# Altium schematic sheet InputOutput.SchDoc(InputOutput)
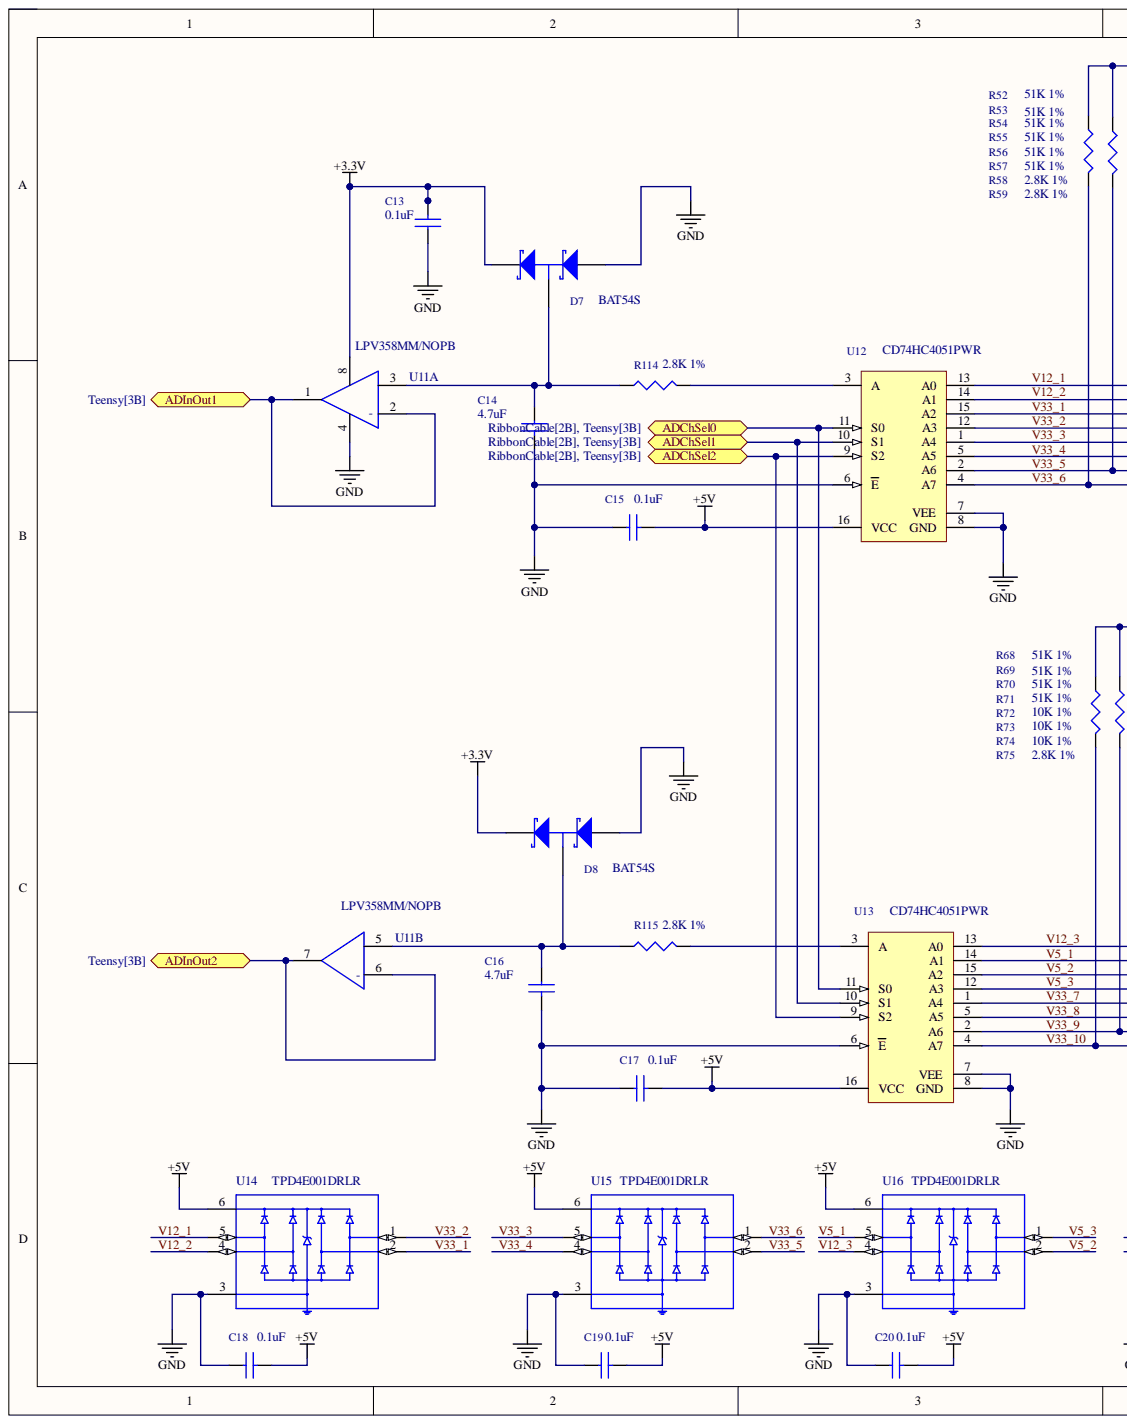
[diagram p0: InputOutput.SchDoc, left]
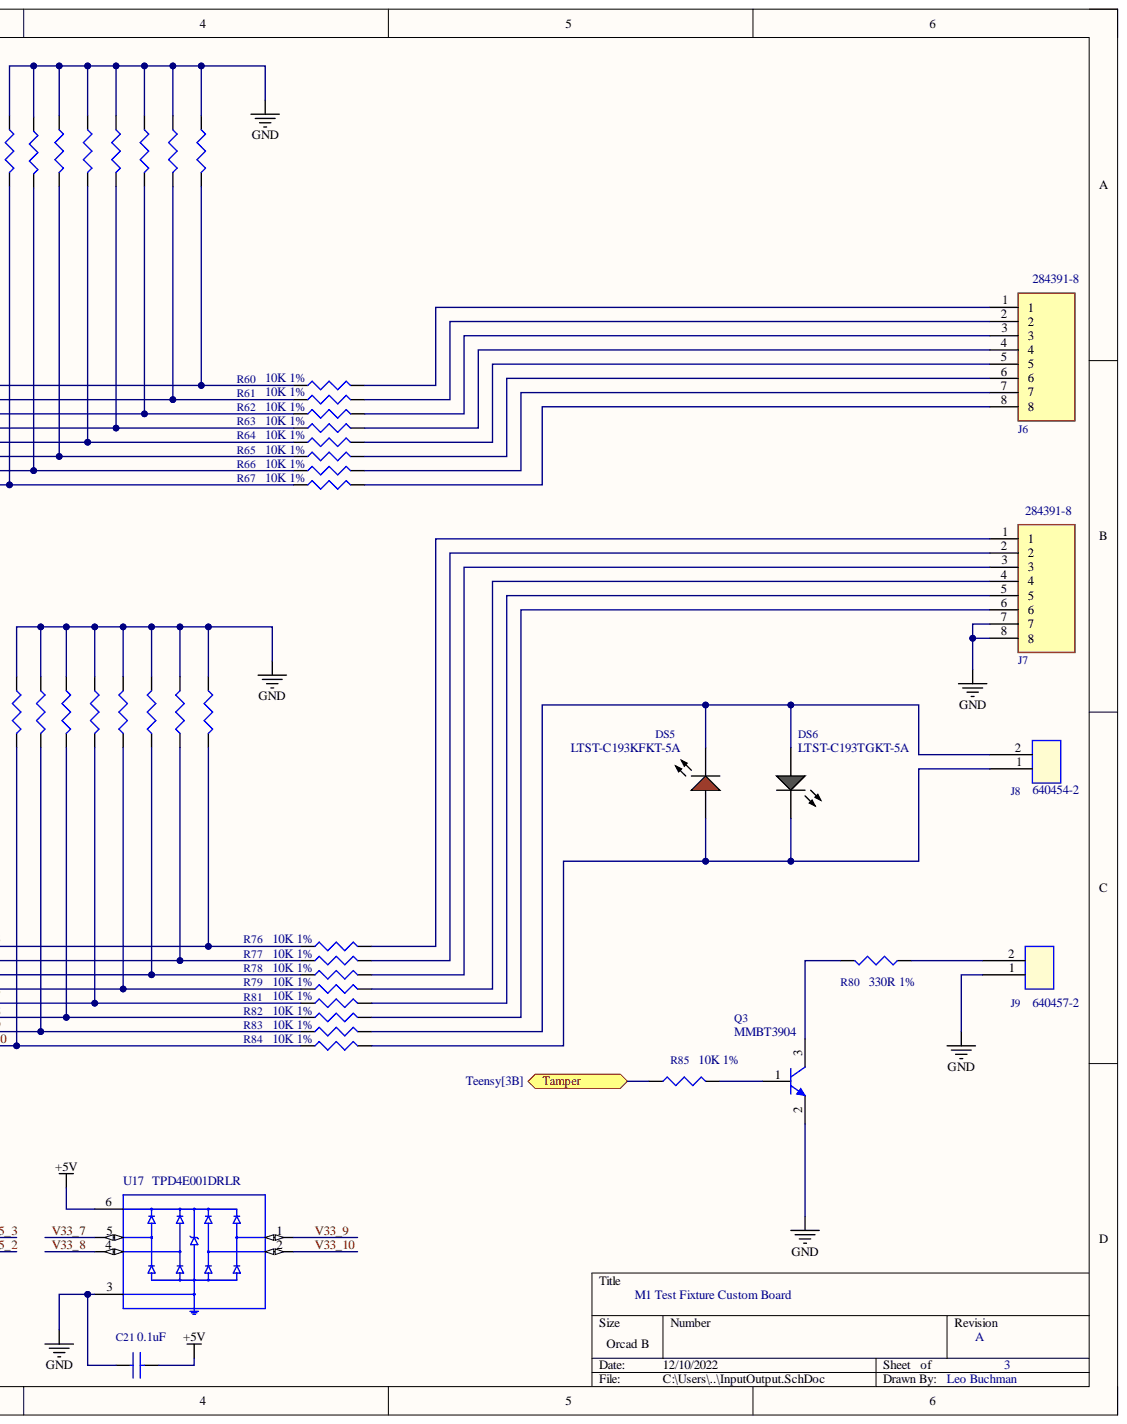
[diagram p1: InputOutput.SchDoc, right]

## Parts
C13  0.1uF  [C0402]
C14  4.7uF  [C0603]
C15  0.1uF  [C0402]
C16  4.7uF  [C0603]
C17  0.1uF  [C0402]
C18  0.1uF  [C0402]
C19  0.1uF  [C0402]
C20  0.1uF  [C0402]
C21  0.1uF  [C0402]
D7  BAT54S  [sot23-3]
D8  BAT54S  [sot23-3]
DS5  LTST-C193KFKT-5A  [LED-ORANGE_0603]
DS6  LTST-C193TGKT-5A  [LED-GREEN_0603]
J6  284391-8  [TE_284391-8]
J7  284391-8  [TE_284391-8]
J8  640454-2  [CON$5C$2P$5C$100LM]
J9  640457-2  [TE_640457-2]
Q3  MMBT3904  [sot23-3]
R52  51K 1%  Tolerance: 1%  [R0402]
R53  51K 1%  [R0402]
R54  51K 1%  [R0402]
R55  51K 1%  [R0402]
R56  51K 1%  [R0402]
R57  51K 1%  [R0402]
R58  2.8K 1%  [R0402]
R59  2.8K 1%  [R0402]
R60  10K 1%  Tolerance: 1%  [R0402]
R61  10K 1%  Tolerance: 1%  [R0402]
R62  10K 1%  Tolerance: 1%  [R0402]
R63  10K 1%  Tolerance: 1%  [R0402]
R64  10K 1%  Tolerance: 1%  [R0402]
R65  10K 1%  Tolerance: 1%  [R0402]
R66  10K 1%  Tolerance: 1%  [R0402]
R67  10K 1%  Tolerance: 1%  [R0402]
R68  51K 1%  Tolerance: 1%  [R0402]
R69  51K 1%  [R0402]
R70  51K 1%  [R0402]
R71  51K 1%  [R0402]
R72  10K 1%  [R0402]
R73  10K 1%  [R0402]
R74  10K 1%  [R0402]
R75  2.8K 1%  [R0402]
R76  10K 1%  Tolerance: 1%  [R0402]
R77  10K 1%  Tolerance: 1%  [R0402]
R78  10K 1%  Tolerance: 1%  [R0402]
R79  10K 1%  Tolerance: 1%  [R0402]
R80  330R 1%  Resistance: 330R  Tolerance: 1%  [R0402]
R81  10K 1%  Tolerance: 1%  [R0402]
R82  10K 1%  Tolerance: 1%  [R0402]
R83  10K 1%  Tolerance: 1%  [R0402]
R84  10K 1%  Tolerance: 1%  [R0402]
R85  10K 1%  Tolerance: 1%  [R0402]
R114  2.8K 1%  Tolerance: 1%  [R0402]
R115  2.8K 1%  Tolerance: 1%  [R0402]
U11  LPV358MM/NOPB  [MUA08A]  (parts A,B)
U12  CD74HC4051PWR  [16-TSSOP-4.4mm]
U13  CD74HC4051PWR  [16-TSSOP-4.4mm]
U14  TPD4E001DRLR  [SOTFL50P160X60-6N]
U15  TPD4E001DRLR  [SOTFL50P160X60-6N]
U16  TPD4E001DRLR  [SOTFL50P160X60-6N]
U17  TPD4E001DRLR  [SOTFL50P160X60-6N]

## Ports
ADChSel0, ADChSel1, ADChSel2, ADInOut1, ADInOut2, Tamper

## Nets
+3.3V: C13.2 D7.2 D8.2 U11.8
+5V: C15.2 C17.2 C18.2 C19.2 C20.2 C21.2 U12.16(VCC) U13.16(VCC) U14.6 U15.6 U16.6 U17.6
GND: C13.1 C14.2 C15.1 C16.2 C17.1 C18.1 C19.1 C20.1 C21.1 D7.1 D8.1 J7.7 J7.8 J9.1 Q3.2 R52.1 R53.1 R54.1 R55.1 R56.1 R57.1 R58.1 R59.1 R68.1 R69.1 R70.1 R71.1 R72.2 R73.2 R74.2 R75.1 U11.4 U12.6(E) U12.7(VEE) U12.8(GND) U13.6(E) U13.7(VEE) U13.8(GND) U14.3 U15.3 U16.3 U17.3
ADChSel0: U12.11(S0) U13.11(S0) ; port ADChSel0
ADChSel1: U12.10(S1) U13.10(S1) ; port ADChSel1
ADChSel2: U12.9(S2) U13.9(S2) ; port ADChSel2
ADInOut1: U11.1 U11.2(-) ; port ADInOut1
ADInOut2: U11.6(-) U11.7 ; port ADInOut2
Tamper: R85.1 ; port Tamper
V5_1: R74.1 R77.1 U13.14(A1) U16.5
V5_2: R73.1 R78.1 U13.15(A2) U16.2
V5_3: R72.1 R79.1 U13.12(A3) U16.1
V12_1: R59.2 R60.1 U12.13(A0) U14.5
V12_2: R58.2 R61.1 U12.14(A1) U14.4
V12_3: R75.2 R76.1 U13.13(A0) U16.4
V33_1: R57.2 R62.1 U12.15(A2) U14.2
V33_2: R56.2 R63.1 U12.12(A3) U14.1
V33_3: R55.2 R64.1 U12.1(A4) U15.5
V33_4: R54.2 R65.1 U12.5(A5) U15.4
V33_5: R53.2 R66.1 U12.2(A6) U15.2
V33_6: R52.2 R67.1 U12.4(A7) U15.1
V33_7: R71.2 R81.1 U13.1(A4) U17.5
V33_8: R70.2 R82.1 U13.5(A5) U17.4
V33_9: R69.2 R83.1 U13.2(A6) U17.1
V33_10: R68.2 R84.1 U13.4(A7) U17.2
NetC14_1: C14.1 D7.3 R114.1 U11.3
NetC16_1: C16.1 D8.3 R115.1 U11.5
NetDS5_1: DS5.1 DS6.2 J8.1 R84.2
NetDS5_2: DS5.2 DS6.1 J8.2 R83.2
NetJ6_1: J6.1 R60.2
NetJ6_2: J6.2 R61.2
NetJ6_3: J6.3 R62.2
NetJ6_4: J6.4 R63.2
NetJ6_5: J6.5 R64.2
NetJ6_6: J6.6 R65.2
NetJ6_7: J6.7 R66.2
NetJ6_8: J6.8 R67.2
NetJ7_1: J7.1 R76.2
NetJ7_2: J7.2 R77.2
NetJ7_3: J7.3 R78.2
NetJ7_4: J7.4 R79.2
NetJ7_5: J7.5 R81.2
NetJ7_6: J7.6 R82.2
NetJ9_2: J9.2 R80.1
NetQ3_1: Q3.1 R85.2
NetQ3_3: Q3.3 R80.2
NetR114_2: R114.2 U12.3(A)
NetR115_2: R115.2 U13.3(A)

## Library data of the parts
C13 C15 C17-C21 = Cap-00005: Cap Ceramic 0.1uF 50V X7R 10% Pad SMD 0402 125C Automotive T/R; Manufacturer: Murata; Manufacturer Part Number: GRT155R71H104KE01D; Database Table Name: Capacitors; Case Code (Imperial): 0402; Case Code (Metric): 1005; Case/Package: 0402; Category: Ceramic Capacitors; Component Kind: Standard; Dielectric Material: Ceramic; LCSC Part Number: C307331; Mount: Surface Mount; Number of Pins: 2; Part Name: Cap-00005; Pricing 1: 1=0.10000, 10=0.04700, 50=0.02580, 100=0.0211; RoHS: Yes; RoHS Compliant: Yes; Stock 1: 179948; Supplier 1: Digi-Key; Supplier Part Number 1: 490-GRT155R71H104KE01DCT-ND; Voltage Rating (DC): 50V; Library Name: Leo-Home-SQL-Lib.DbLib
C14 C16 = Cap-00001: CAP CER 4.7UF 16V X5R 0603; Manufacturer: Samsung; Manufacturer Part Number: CL10A475KO8NNNC; Database Table Name: Capacitors; Dielectric Material: Ceramic; Tolerance: 10%; Capacitance: 4.7uF; Case Code (Imperial): 0603; Case Code (Metric): 1608; Case/Package: 0603; Category: Ceramic Capacitors; Component Kind: Standard; ComponentLink1Description: https://datasheet.ciiva.com/25795/cl10a475ko8; ComponentLink1URL: https://datasheet.ciiva.com/25795/cl10a475ko8; ComponentLink2Description: https://datasheet.ciiva.com/26838/getdatashee; ComponentLink2URL: https://datasheet.ciiva.com/26838/getdatashee; ComponentLink3Description: https://datasheet.ciiva.com/29198/457663-da-0; ComponentLink3URL: https://datasheet.ciiva.com/29198/457663-da-0; Construction: Flat; Contact Plating: Tin; Depth: 0.8mm; Dielectric: X5R; Height: 0.8mm; LCSC Part Number: C19666; Length: 1.6mm; Material: Ceramic; Max Operating Temperature: 85°C; Min Operating Temperature: -55°C; Mount: Surface Mount; Number of Pins: 2; Package Quantity: 1; Packaging: Tape and Reel; Part Name: Cap-00001; Pricing 1: 1=0.13000, 10=0.09100, 50=0.05180, 100=0.0429; Radiation Hardening: No; RoHS: Yes; RoHS Compliant: Yes; Series: CL; Stock 1: 1593998; Supplier 1: Digi-Key; Supplier Part Number 1: 1276-1784-1-ND; Thickness: 0.035inch; Voltage: 16V; Voltage Rating: 16V; Width: 0.031inch; Library Name: Leo-Home-SQL-Lib.DbLib
D7 D8 = Diode-0009: DIODE SCHOTTKY 30V 150MA SOT23-3; Manufacturer: Sangdest Microelectronics; Database Table Name: Diodes; Elements: 2; Package Version: Rev. 12, 03/2016; Peak Reverse Current: 2uA; Recovery Time: 5ns; Component Kind: Standard; Component Type: Diodes; Datasheet URL: https://www.fairchildsemi.com/datasheets/BA/BAT54S.pdf; Datasheet Version: Rev. 2.3, 04/2016; LCSC Part Number: C47546; Lead Free: Lead Free; Manufacturer Part Number: BAT54S; Manufacturer URL: https://www.fairchildsemi.com/; Mount: Surface Mount; Mounting Technology: SM; Package Description: 3-Pin Small Outline Transistor, Body 2.92 x 1.325 mm, Pitch 0.95 mm; Package Reference: SOT23-3; Package URL: http://www.fairchildsemi.com/dwg/MA/MA03D.pdf; Part Name: Diode-0009; Pins: 3; Pricing 1: 1=0.43000, 10=0.35100, 100=0.18620, 500=0.122; Radiation Hardening: No; RoHS: Yes; Stock 1: 168252; Supplier 1: Digi-Key; Supplier Part Number 1: 1655-BAT54SCT-ND; Library Name: Leo-Home-SQL-Lib.DbLib
DS5 = Diode-0004: LED Orange CLEAR 0603 SMD; Manufacturer: Vishay Lite-On; Database Table Name: Diodes; Dominant Wavelength: 605nm; Forward (Drive) Current: 20mA; Forward Current: 5mA; Forward Voltage: 2.0V; Intensity: 22mcd; Lens Color: Colorless; Lens Style: Diffused,Colorless,Rectangular,Clear; Luminous Intensity: 28.1mcd; Number of Elements: 1; Viewing Angle: 130°; Case Code (Imperial): 0603; Case Code (Metric): 1608; Case/Package: 0603; Category: LEDs; Color: Orange; Component Kind: Standard; Datasheet URL: https://datasheet.ciiva.com/11773/0900766b80df3ad8-11773825.pdf; Datasheet Version: Rev. 2.0, 03/2010; Dimension: 1.6x0.8x0.36mm; Height: 0.35mm; Illumination Color: Orange; LCSC Part Number: C434425; Lead Free: Lead Free; Lens Transparency: Clear; Lens Type: Clear; Manufacturer Part Number: LTST-C193KFKT-5A; Manufacturer URL: http://www.rohm.com/web/global/; Max Operating Temperature: 85°C; Min Operating Temperature: -55°C; Mount: Surface Mount; Mounting Technology: SurfaceMount; Number of Pins: 2; Package Description: 2-Pin Surface Mount Device, Body 1.6 x 0.8 mm; Package Reference: 1608; Packaging: Tape and Reel; Part Name: Diode-0004; Pricing 1: 1=0.39000, 10=0.20900, 100=0.09720, 500=0.089; Radiation Hardening: No; RoHS: Yes; RoHS Compliant: Yes; Stock 1: 55639; Supplier 1: Digi-Key; Supplier Part Number 1: 160-1829-1-ND; Width: 0.8mm; Library Name: Leo-Home-SQL-Lib.DbLib
DS6 = Diode-0007: LED Green CLEAR 0603 SMD; Manufacturer: Vishay Lite-On; Access Time: 3500 ns; Average Rectified Current: 2A; Case\Package: SOIC; Clock Frequency: 100 KHz; Database Table Name: Diodes; Density: 32 kb; Dominant Wavelength: 535nm; Element Configuration: Single; Forward Current: 5mA; Forward Voltage: 2.0V; Frequency: 400 KHz; Lens Color: Colorless; Lens Style: Diffused,Colorless,Rectangular; Luminous Intensity: 280mcd; Max Repetitive Reverse Voltage (Vrrm): 40V; Max Reverse Current: 500uA; Max Supply Voltage: 5.5 V; Min Supply Voltage: 1.7 V; Nominal Supply Current: 2 mA; Number of Elements: 1; Peak Non-Repetitive Surge Current: 50A; Power Dissipation: 76mW; Reverse Voltage: 5V; Viewing Angle: 130°; Case Code (Imperial): 0603; Case Code (Metric): 1608; Case/Package: 0603; Category: LEDs; Color: Green; Component Kind: Standard; ComponentLink1Description: https://datasheet.ciiva.com/2111/ltst-c193tgk; ComponentLink1URL: https://datasheet.ciiva.com/2111/ltst-c193tgk; ComponentLink2Description: https://datasheet.ciiva.com/11775/0900766b80d; ComponentLink2URL: https://datasheet.ciiva.com/11775/0900766b80d; ComponentLink3Description: https://datasheet.ciiva.com/4689/lite-on-ltst; ComponentLink3URL: https://datasheet.ciiva.com/4689/lite-on-ltst; ComponentLink4Description: https://datasheet.ciiva.com/2111/p-100-ltst-c; ComponentLink4URL: https://datasheet.ciiva.com/2111/p-100-ltst-c; ComponentLink5Description: https://datasheet.ciiva.com/2111/p-100-ltst-c; ComponentLink5URL: https://datasheet.ciiva.com/2111/p-100-ltst-c; ComponentLink6Description: http://www.mouser.com/ds/2/115/B220AQ_Q-B260A; ComponentLink6URL: http://www.mouser.com/ds/2/115/B220AQ_Q-B260A; ComponentLink7Description: https://www.diodes.com/assets/Datasheets/ds13; ComponentLink7URL: https://www.diodes.com/assets/Datasheets/ds13; ComponentLink8Description: http://www.diodes.com/datasheets/ds13004.pdf?; ComponentLink8URL: http://www.diodes.com/datasheets/ds13004.pdf?; Contact Plating: Tin,Matte; DatasheetVersion: Mar-12; Depth: 0.8mm; Height: 0.35mm; Illumination Color: Green; LCSC Part Number: C72043; Lead Free: Lead Free; Length: 1.6mm; Lens Transparency: Clear; Manufacturer Part Number: LTST-C193TGKT-5A; Max Clock Frequency: 100 KHz; Max Operating Temperature: 80°C; Max Reverse Voltage (DC): 40V; Min Operating Temperature: -20°C; Mount: Surface Mount; Number of LEDs: 1; Number of Pins: 2; Package Quantity: 3000; PackageDescription: 2-Pin Surface Mount Device, Body 1.6 x 0.8 mm, Height 0.9 mm; PackageReference: HSMX-C190; Packaging: Tape and Reel; Part Name: Diode-0007; Pins: 8; Pricing 1: 1=0.45000, 10=0.27400, 100=0.15780, 1000=0.12; Radiation Hardening: No; RoHS: Yes; RoHS Compliant: Yes; RoHSCompliant: true; Series: -; Stock 1: 429188; Supplier 1: Digi-Key; Supplier Part Number 1: 160-1832-1-ND; Test Current: 5mA; Width: 0.8mm; Library Name: Leo-Home-SQL-Lib.DbLib
J6 J7 = CON-0011: Conn Terminal Block F 8 POS 3.5mm Solder ST T; Manufacturer: TE Connectivity; Database Table Name: Connectors; Category: Terminal Blocks; Color: Green; Component Kind: Standard; Contact Material: Brass; Contact Plating: Tin; Digi-Key_Part_Number: A98163-ND; Digi-Key_Purchase_URL: https://www.digikey.com.au/product-detail/en/te-connectivity-amp-connectors/284391-8/A98163-ND/1827025?utm_source=snapeda&utm_medium=aggregator&utm_campaign=symbol; EU_RoHS_Compliance: Compliant with Exemptions; LCSC Part Number: N/A; Manufacturer Part Number: 284391-8; MF: TE Connectivity; Mount: Through Hole; MP: 284391-8; Package: None; Part Name: CON-0011; Pricing 1: 1=5.54000, 10=3.52000, 50=3.52000, 100=3.4300; RoHS: Yes; SnapEDA_Link: https://www.snapeda.com/parts/284391-8/TE%20Connectivity%20AMP%20Connectors/view-part/2375722/?ref=snap; Stock 1: 530; Supplier 1: Mouser; Supplier Part Number 1: 571-284391-8; TE_purchase_URL: https://www.te.com/usa-en/product-284391-8.html?te_bu=Cor&te_type=disp&te_campaign=seda_glo_cor-seda-global-disp-prtnr-fy19-seda-model-bom-cta_sma-317_1&elqCampaignId=32493; Library Name: Leo-Home-SQL-Lib.DbLib
J8 = CON-0013: Header, Polarized; MTA-100; 2; 250 VAC; Polye; Manufacturer: TE Connectivity; Database Table Name: Connectors; Parameter 1: C86502; Category: Connectors; Color: Natural,White,Beige; Component Kind: Standard; Contact Material: Copper Alloy; Contact Plating: Tin; Contact Shape: Square; Housing Color: Natural; Housing Material: Polyester; LCSC Part Number: C86501; Manufacturer Part Number: 640454-2; Mount: Through Hole; Part Name: CON-0013; Pricing 1: 1=0.16000, 10=0.12600, 100=0.09280, 500=0.076; RoHS: Yes; Stock 1: 22525; Supplier 1: Digi-Key; Supplier Part Number 1: A19423-ND; Library Name: Leo-Home-SQL-Lib.DbLib
J9 = CON-0014: MTA-100 HEADER  Friction Lock R/A 2POS 2.54MM; Manufacturer: AMP - TE CONNECTIVITY; Database Table Name: Connectors; Parameter 1: C86502; Component Kind: Standard; ComponentLink1Description: http://www.farnell.com/datasheets/2007196.pdf; ComponentLink1URL: http://www.farnell.com/datasheets/2007196.pdf; ComponentLink2Description: http://www.farnell.com/datasheets/2007196.pdf; ComponentLink2URL: http://www.farnell.com/datasheets/2007196.pdf; ComponentLink3Description: http://www.farnell.com/datasheets/2007196.pdf; ComponentLink3URL: http://www.farnell.com/datasheets/2007196.pdf; LCSC Part Number: C86507; Manufacturer Part Number: 640457-2; Part Name: CON-0014; RoHS: No; Supplier 1: Digi-Key; Supplier Part Number 1: A1926-ND; Library Name: Leo-Home-SQL-Lib.DbLib
Q3 = Tran-0002: Trans GP BJT NPN 60V 0.2A 350mW Automotive 3-Pin SOT-23 T/R; Manufacturer: ON Semiconductor / Fairchild; Collector-emitter Voltage-Max: 400mV; Database Table Name: Transistors; Elements: 1; Frequency: 250MHz; Max Collector Current: 200mA; Power: 225mW; Vce Max: 300mV; Case/Package: SOT-23-3; Component Kind: Standard; Component Type: Transistors; ComponentLink1Description: https://datasheet.ciiva.com/22664/1708270-226; ComponentLink1URL: https://datasheet.ciiva.com/22664/1708270-226; ComponentLink2Description: https://datasheet.ciiva.com/4721/mmbt3906lt1-; ComponentLink2URL: https://datasheet.ciiva.com/4721/mmbt3906lt1-; ComponentLink3Description: https://datasheet.ciiva.com/11201/mmbt3906lt1; ComponentLink3URL: https://datasheet.ciiva.com/11201/mmbt3906lt1; ComponentLink4Description: https://datasheet.ciiva.com/16387/mmbt3906lt1; ComponentLink4URL: https://datasheet.ciiva.com/16387/mmbt3906lt1; ComponentLink5Description: https://datasheet.ciiva.com/976/mmbt3906lt1-d; ComponentLink5URL: https://datasheet.ciiva.com/976/mmbt3906lt1-d; ComponentLink6Description: https://datasheet.ciiva.com/976/mmbt3906lt1-d; ComponentLink6URL: https://datasheet.ciiva.com/976/mmbt3906lt1-d; ComponentLink7Description: https://datasheet.ciiva.com/976/mmbt3906lt1-d; ComponentLink7URL: https://datasheet.ciiva.com/976/mmbt3906lt1-d; Datasheet URL: http://www.onsemi.cn/pub_link/Collateral/MMBT3904LT1-D.PDF; Datasheet Version: Rev. 12, 11/2012; Height: 0.94mm; LCSC Part Number: C20526; Lead Free: Lead Free; Length: 2.9mm; Manufacturer Part Number: MMBT3904; Max Frequency: 250MHz; Max Operating Temperature: 150°C; Min Operating Temperature: -55°C; Mount: Surface Mount; Mounting Technology: SM; Number of Elements: 1; Package Description: 3-Pin Small Outline Transistor, Body 2.9 x 1.3 mm, Pitch 0.95 mm; Package Reference: SOT-23 (318-08); Part Name: Tran-0002; Pins: 3; Polarity: NPN; Radiation Hardening: No; REACH SVHC: No SVHC; RoHS: Yes; RoHS Compliant: Yes; Series: -; Supplier 1: Newark; Supplier Part Number 1: 58K9431; Width: 1.3mm; Library Name: Leo-Home-SQL-Lib.DbLib
R52-R57 R68-R71 = Res-00011: Res Thick Film 0402 51K Ohm 1% 0.063W(1/16W) ±100ppm/C Pad SMD Automotive T/R; Manufacturer: Stackpole Electronics; Manufacturer Part Number: RMCF0402FT51K0; Database Table Name: Resistors; Max Power Dissipation: 63mW; Resistance: 51kR; Temperature Coefficient: 100ppm/°C; Case Code (Imperial): 0402; Case Code (Metric): 1005; Case/Package: 0402; Category: Chip SMD Resistors; Component Kind: Standard; ComponentLink1Description: http://components.arrow.com/part/dataview/535; ComponentLink1URL: http://components.arrow.com/part/dataview/535; ComponentLink2Description: https://www.seielect.com/catalog/SEI-RMCF_RMC; ComponentLink2URL: https://www.seielect.com/catalog/SEI-RMCF_RMC; ComponentLink3Description: https://www.seielect.com/Catalog/SEI-RMCF_RMC; ComponentLink3URL: https://www.seielect.com/Catalog/SEI-RMCF_RMC; ComponentLink4Description: https://www.seielect.com/catalog/SEI-Packagin; ComponentLink4URL: https://www.seielect.com/catalog/SEI-Packagin; Contact Plating: Tin,Matte; Features: Automotive AEC-Q200; Height: 0.016inch; LCSC Part Number: C25794; Length: 0.039inch; Max Operating Temperature: 155°C; Min Operating Temperature: -55°C; Mount: Surface Mount; Number of Terminations: 2; Packaging: Tape and Reel; Part Name: Res-00011; Power Rating: 63mW; Pricing 1: 1=0.10000, 10=0.01500, 100=0.00610, 1000=0.00; Radiation Hardening: No; Resistor Type: Thick Film; RoHS: Yes; RoHS Compliant: Yes; Series: RMCF; Stock 1: 588931; Supplier 1: Digi-Key; Supplier Part Number 1: RMCF0402FT51K0CT-ND; Width: 0.02inch; Library Name: Leo-Home-SQL-Lib.DbLib
  R53-R57 R69-R71: Tolerance: 1%
R58 R59 R75 R114 R115 = Res-00008: Thick Film 0402 2.8K Ohm 1% 0.063W ±100ppm/°C Molded SMD Paper T/R; Manufacturer: Stackpole Electronics; Manufacturer Part Number: RMCF0402FT2K80; Database Table Name: Resistors; Max Power Dissipation: 63mW; Power Rating: 62.5mW; Resistance: 2.8kR; Case Code (Imperial): 0402; Case Code (Metric): 1005; Case/Package: 0402; Category: Chip SMD Resistors; Component Kind: Standard; ComponentLink1Description: https://www.seielect.com/catalog/SEI-RMCF_RMC; ComponentLink1URL: https://www.seielect.com/catalog/SEI-RMCF_RMC; ComponentLink2Description: https://www.seielect.com/Catalog/SEI-RMCF_RMC; ComponentLink2URL: https://www.seielect.com/Catalog/SEI-RMCF_RMC; ComponentLink3Description: https://www.seielect.com/catalog/SEI-Packagin; ComponentLink3URL: https://www.seielect.com/catalog/SEI-Packagin; Contact Plating: Tin,Matte; Features: Automotive AEC-Q200; Height: 0.016inch; LCSC Part Number: C62741; Lead Free: Lead Free; Length: 0.039inch; Max Operating Temperature: 155°C; Min Operating Temperature: -55°C; Mount: Surface Mount; Number of Terminations: 2; Packaging: Tape and Reel; Part Name: Res-00008; Pricing 1: 1=0.10000, 10=0.01500, 100=0.00610, 1000=0.00; Radiation Hardening: No; Resistor Type: Thick Film; RoHS: Yes; RoHS Compliant: Yes; Series: RMCF; Stock 1: 141882; Supplier 1: Digi-Key; Supplier Part Number 1: RMCF0402FT2K80CT-ND; Temperature Coefficient: 100ppm/°C; Width: 0.02inch; Library Name: Leo-Home-SQL-Lib.DbLib
  R58 R59 R75: Tolerance: 1%
R60-R67 R72-R74 R76-R79 R81-R85 = Res-00002: Thick Film 0402 10K OHM 1% 1/16W 0402; Manufacturer: Yageo; Manufacturer Part Number: RC0402FR-0710KP; Database Table Name: Resistors; Max Power Dissipation: 63mW; Power Rating: 62.5mW; Temperature Coefficient: 150ppm/°C; Case Code (Imperial): 0402; Case Code (Metric): 1005; Case/Package: 0402; Category: Chip SMD Resistors; Component Kind: Standard; ComponentLink1Description: http://www.yageo.com/NewPortal/yageodocoutput; ComponentLink1URL: http://www.yageo.com/NewPortal/yageodocoutput; Features: Moisture Resistant; Height: 0.016inch; LCSC Part Number: C25744; Length: 0.039inch; Max Operating Temperature: 155°C; Min Operating Temperature: -55°C; Mount: Surface Mount; Number of Terminations: 2; Packaging: Tape and Reel; Part Name: Res-00002; Pricing 1: 1=0.10000, 10=0.03600, 100=0.01450, 1000=0.00; Resistance: 10k; Resistor Type: Thick Film; RoHS: Yes; RoHS Compliant: Yes; Stock 1: 161500; Supplier 1: Digi-Key; Supplier Part Number 1: YAG1249CT-ND; Width: 0.02inch; Library Name: Leo-Home-SQL-Lib.DbLib
  R72-R74: Tolerance: 1%
R80 = Res-00009: Thick Film 0402 120 Ohm 1% 1/16W ±100ppm/°C Molded SMD Paper T/R; Manufacturer: Yageo; Manufacturer Part Number: AF0402FR-07330RL; Database Table Name: Resistors; Max Power Dissipation: 63mW; Power Rating: 62.5mW; Case Code (Imperial): 0402; Case Code (Metric): 1005; Case/Package: 0402; Category: Chip SMD Resistors; Component Kind: Standard; ComponentLink1Description: http://www.yageo.com/documents/recent/PYu-AF_; ComponentLink1URL: http://www.yageo.com/documents/recent/PYu-AF_; Features: Moisture Resistant,Anti-Sulfur; Height: 0.015inch; LCSC Part Number: C51721; Length: 0.039inch; Max Operating Temperature: 155°C; Min Operating Temperature: -55°C; Mount: Surface Mount; Number of Terminations: 2; Packaging: Tape and Reel; Part Name: Res-00009; Pricing 1: 1=0.10000, 10=0.05800, 100=0.02360, 1000=0.01; Radiation Hardening: No; Resistor Type: Thick Film; RoHS: Yes; RoHS Compliant: Yes; Stock 1: 15948; Supplier 1: Digi-Key; Supplier Part Number 1: 13-AF0402FR-07330RLCT-ND; Temperature Coefficient: 100ppm/°C; Width: 0.02inch; Library Name: Leo-Home-SQL-Lib.DbLib
U11 = amp-00002: Op Amp Dual Micropower Amplifier R-R O/P 5V 8; Manufacturer: TI National Semiconductor; Amplifier Type: GENERAL PURPOSE; Bandwidth: 152kHz; Common Mode Rejection Ratio: 50dB; Database Table Name: Amplifiers; Dual Supply Voltage: 9V; Input Bias Current: 2nA; Input Offset Voltage (Vos): 1.5mV; Max Dual Supply Voltage: 16V; Max Frequency: 1kHz; Min Dual Supply Voltage: 1.5V; Min Supply Voltage: 2.7V; Nominal Supply Current: 15uA; Number of Amplifiers: 2; Operating Supply Voltage: 3V; Output Current: 2mA; Output Current per Channel: 16mA; Slew Rate: 0.1V/µs; Voltage Gain: 100dB; Case/Package: VSSOP; Category: Amplifiers - Op Amps, Buffer, Instrumentation; Component Kind: Standard; ComponentLink1Description: https://datasheet.ciiva.com/6627/lpv321-n-662; ComponentLink1URL: https://datasheet.ciiva.com/6627/lpv321-n-662; ComponentLink2Description: https://datasheet.ciiva.com/6627/lpv321-n-662; ComponentLink2URL: https://datasheet.ciiva.com/6627/lpv321-n-662; ComponentLink3Description: https://datasheet.ciiva.com/6627/lpv321-n-662; ComponentLink3URL: https://datasheet.ciiva.com/6627/lpv321-n-662; Contact Plating: Tin; Gain Bandwidth Product: 152kHz; Height: 0.95mm; LCSC Part Number: C133971; Lead Free: Lead Free; Length: 3.1mm; Manufacturer Part Number: LPV358MM/NOPB; Max Operating Temperature: 85°C; Max Supply Voltage: 5V; Min Operating Temperature: -40°C; Mount: Surface Mount; Number of Channels: 2; Number of Circuits: 2; Number of Elements: 2; Number of Pins: 8; Operating Supply Current: 15uA; Package Quantity: 1000; Packaging: Tape and Reel; Part Name: amp-00002; Power Supply Rejection Ratio (PSRR): 50dB; Pricing 1: 1=1.43000, 10=1.27600, 25=1.21080, 100=0.9947; Radiation Hardening: No; REACH SVHC: No SVHC; RoHS: Yes; RoHS Compliant: Yes; Stock 1: 8722; Supplier 1: Digi-Key; Supplier Part Number 1: LPV358MM/NOPBCT-ND; Technology: BICMOS; Unity Gain Bandwidth Product: 152kHz; Width: 3.1mm; Library Name: Leo-Home-SQL-Lib.DbLib
U12 U13 = AIC-0001: 5-V, 8: 1, 1-channel analog mutliplexer 16-TS; Manufacturer: Texas Instruments; Bandwidth: 180MHz; Bandwidth(Max)(MHz): 180; Configuration: 1 X 1：8 MUX; Crosstalk: Yes; Database Table Name: Analog-ICs; Dual Supply Voltage: 3V; High Level Output Current: 25mA; Logic Function: Multiplexer,Demultiplexer; Max Dual Supply Voltage: 5V; Max Supply Voltage: 6V; Min Dual Supply Voltage: 1V; Min Supply Voltage: 2V; Nominal Supply Current: 16uA; Number of Bits: 8; Number of Channels: 1; On-State Resistance: 130R; Output Type: CMOS; Polarity: Non-Inverting; Propagation Delay: 60ns; Rating: Catalog; Ron(Max)(Ohms): 130; Ron(Typ)(Ohms): 45; Supply Type: Single,Dual; Turn-Off Delay Time: 225ns; VCC(Max)(V): 6; VCC(Min)(V): 2; Case/Package: TSSOP; Category: Decoders and Multiplexers; Code_JEDEC: MS-012 variation AC; Component Kind: Standard; ComponentLink1Description: https://datasheet.ciiva.com/15127/getdatashee; ComponentLink1URL: https://datasheet.ciiva.com/15127/getdatashee; ComponentLink2Description: https://datasheet.ciiva.com/27092/1326571-270; ComponentLink2URL: https://datasheet.ciiva.com/27092/1326571-270; ComponentLink3Description: https://datasheet.ciiva.com/1514/1789854-1514; ComponentLink3URL: https://datasheet.ciiva.com/1514/1789854-1514; ComponentLink4Description: https://datasheet.ciiva.com/1171/1633734-1171; ComponentLink4URL: https://datasheet.ciiva.com/1171/1633734-1171; ComponentLink5Description: https://datasheet.ciiva.com/1171/1633734-1171; ComponentLink5URL: https://datasheet.ciiva.com/1171/1633734-1171; ComponentLink6Description: https://datasheet.ciiva.com/2978/cd74hct4053-; ComponentLink6URL: https://datasheet.ciiva.com/2978/cd74hct4053-; ComponentLink7Description: https://datasheet.ciiva.com/46391/1840262-463; ComponentLink7URL: https://datasheet.ciiva.com/46391/1840262-463; Contact Plating: Gold; DatasheetVersion: SCHS122J; Generic Part Number: CD74HC4051; Height: 1.15mm; LCSC Part Number: C352826; Lead Free: Lead Free; Length: 5mm; Low Level Output Current: 25mA; Manufacturer Part Number: CD74HC4051PWR; Max Operating Temperature: 125°C; Max Output Current: 25mA; Min Operating Temperature: -55°C; Mount: Surface Mount; Number of Circuits: 1; Number of Inputs: 8; Number of Outputs: 1; Number of Pins: 16; Number of Switches: 1; Operating Temperature Range(C): -55 to 125; Package Quantity: 1; PackageReference: D0016A; PackageVersion: http://www.ti.com/lit/ml/mpds178g/mpds178g.pdf; Packaging: Tape and Reel; Part Name: AIC-0001; Pricing 1: 1=0.33420, 10=0.26780, 30=0.23940, 100=0.2039; Radiation Hardening: No; REACH SVHC: No SVHC; RoHS: Yes; RoHS Compliant: Yes; Series: 74HC; Stock 1: 12645; Supplier 1: Newark; Supplier Part Number 1: 29AH3620; Supply Current: 16uA; Termination: SMD/SMT; Turn-On Delay Time: 225ns; Weight: 0.002183oz; Width: 4.4mm; Library Name: Leo-Home-SQL-Lib.DbLib
U14-U17 = Diode-0012: TVS DIODE 5.5VWM SOT6; Manufacturer: Texas Instruments; Breakdown Voltage: 11V; Capacitance: 1.5pF; Clamping Voltage: 105.5V; Database Table Name: Diodes; Direction: Unidirectional; Interface: LVDS,USB,Ethernet; Leakage Current: 1nA; Max Power Dissipation: 100W; Number of Bidirectional Channels: 4; Operating Supply Voltage: 5.5V; Peak Pulse Current: 5.5A; Power Line Protection: Yes; Case/Package: SOT; Category: ESD and Circuit Protection ICs; Component Kind: Standard; ComponentLink1Description: https://datasheet.ciiva.com/5839/1535398-5839; ComponentLink1URL: https://datasheet.ciiva.com/5839/1535398-5839; ComponentLink2Description: https://datasheet.ciiva.com/22696/1831342-226; ComponentLink2URL: https://datasheet.ciiva.com/22696/1831342-226; ComponentLink3Description: https://datasheet.ciiva.com/1219/tpd4e001-121; ComponentLink3URL: https://datasheet.ciiva.com/1219/tpd4e001-121; ComponentLink4Description: https://datasheet.ciiva.com/15134/getdatashee; ComponentLink4URL: https://datasheet.ciiva.com/15134/getdatashee; ComponentLink5Description: https://datasheet.ciiva.com/7659/1853592-7659; ComponentLink5URL: https://datasheet.ciiva.com/7659/1853592-7659; ComponentLink6Description: https://datasheet.ciiva.com/338/tpd4e001-3380; ComponentLink6URL: https://datasheet.ciiva.com/338/tpd4e001-3380; ComponentLink7Description: https://datasheet.ciiva.com/338/tpd4e001-3380; ComponentLink7URL: https://datasheet.ciiva.com/338/tpd4e001-3380; ComponentLink8Description: https://datasheet.ciiva.com/338/tpd4e001-3380; ComponentLink8URL: https://datasheet.ciiva.com/338/tpd4e001-3380; ComponentLink9Description: https://datasheet.ciiva.com/14423/tpd4e001-14; ComponentLink9URL: https://datasheet.ciiva.com/14423/tpd4e001-14; Contact Plating: Gold; Depth: 1.2mm; Height: 0.55mm; LCSC Part Number: C527516; Lead Free: Lead Free; Length: 1.6mm; Manufacturer Part Number: TPD4E001DRLR; Max Breakdown Voltage: 11V; Max Operating Temperature: 85°C; Min Breakdown Voltage: 11V; Min Operating Temperature: -40°C; Mount: Surface Mount; Number of Channels: 4; Number of Pins: 6; Package Quantity: 1; Packaging: Tape and Reel; Part Name: Diode-0012; Peak Pulse Power: 100W; Polarity: Unidirectional; Pricing 1: 1=0.66000, 10=0.58100, 100=0.44520, 500=0.351; Radiation Hardening: No; REACH SVHC: No SVHC; Reverse Standoff Voltage: 5.5V; RoHS: Yes; RoHS Compliant: Yes; Series: -; Stock 1: 42388; Supplier 1: Digi-Key; Supplier Part Number 1: 296-21887-1-ND; Termination: SMD/SMT; Weight: 9.9E-05oz; Width: 1.2mm; Working Voltage: 5.5V; Library Name: Leo-Home-SQL-Lib.DbLib
